# Altium schematic sheet SDCard_PMOD_JTAG.SchDoc(SDCard_PMOD_JTAG)
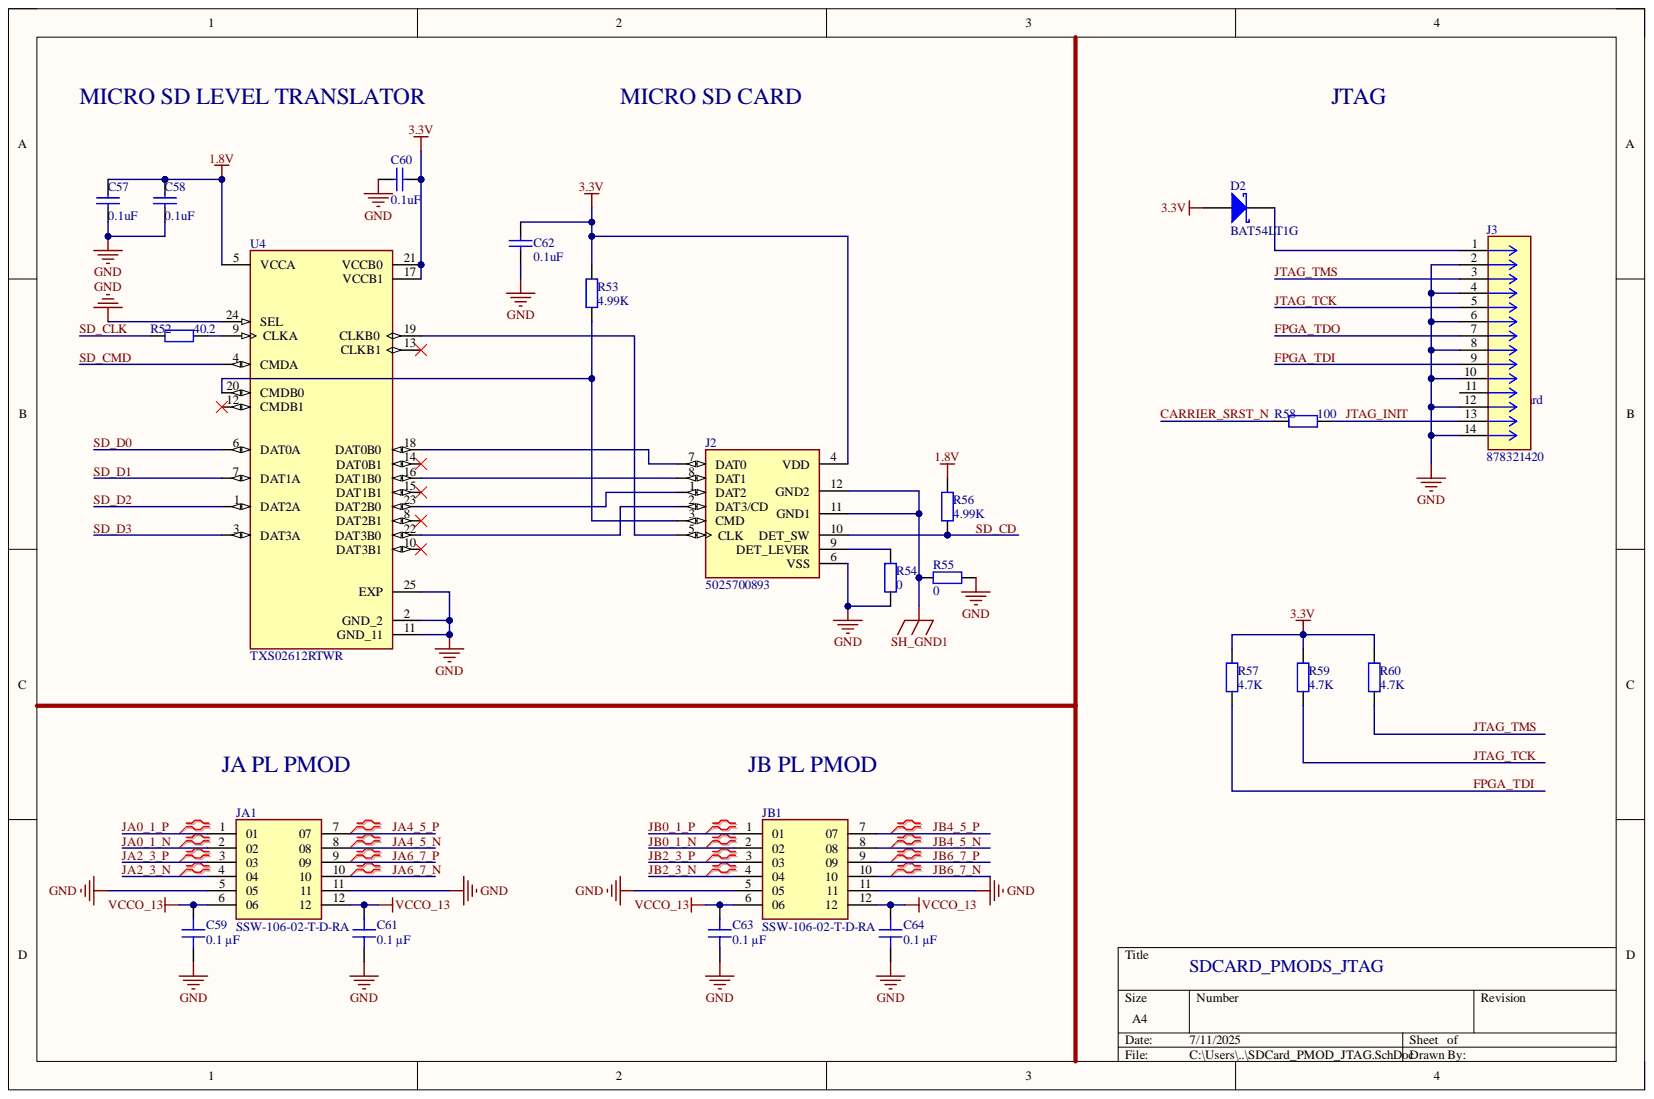
[diagram p0: SDCard_PMOD_JTAG.SchDoc]

## Parts
C57  value 0.1uF  [0603_C]  (hidden comment: Cap)
C58  value 0.1uF  [0603_C]  (hidden comment: Cap)
C59  0.1 µF  [CAP 0603_1608]
C60  value 0.1uF  [0603_C]  (hidden comment: Cap)
C61  0.1 µF  [CAP 0603_1608]
C62  value 0.1uF  [0603_C]  (hidden comment: Cap)
C63  0.1 µF  [CAP 0603_1608]
C64  0.1 µF  [CAP 0603_1608]
D2  BAT54LT1G  [SMB]
J2  5025700893  [MOLEX_5025700893]
J3  878321420  [878321420_MOL]
JA1  SSW-106-02-T-D-RA  [SSW-106-02-T-D-RA]
JB1  SSW-106-02-T-D-RA  [SSW-106-02-T-D-RA]
R52  value 40.2  [0603_R]  (hidden comment: Res2)
R53  value 4.99K  [0603_R]  (hidden comment: Res2)
R54  value 0  [0603_R]  (hidden comment: Res2)
R55  value 0  [0603_R]  (hidden comment: Res2)
R56  value 4.99K  [0603_R]  (hidden comment: Res2)
R57  value 4.7K  [0603_R]  (hidden comment: Res2)
R58  value 100  [0603_R]  (hidden comment: Res2)
R59  value 4.7K  [0603_R]  (hidden comment: Res2)
R60  value 4.7K  [0603_R]  (hidden comment: Res2)
U4  TXS02612RTWR  [TXS02612RTWR]

## Nets
1.8V: C57.2 C58.2 R56.2 U4.5(VCCA)
3.3V: C60.1 C62.1 D2.1 J2.4(VDD) R53.2 R57.2 R59.2 R60.2 U4.17(VCCB1) U4.21(VCCB0)
GND: C57.1 C58.1 C59.1 C60.2 C61.1 C62.2 C63.1 C64.1 J2.6(VSS) J3.2 J3.4 J3.6 J3.8 J3.10 J3.12 J3.14 JA1.5 JA1.11 JB1.5 JB1.11 R54.1 R55.1 U4.2(GND_2) U4.11(GND_11) U4.24(SEL) U4.25(EXP)
SH_GND1: J2.11(GND1) J2.12(GND2) R55.2
VCCO_13: C59.2 C61.2 C63.2 C64.2 JA1.6 JA1.12 JB1.6 JB1.12
CARRIER_SRST_N: R58.1
FPGA_TDI: J3.9 R57.1
FPGA_TDO: J3.7
JA0_1_N: JA1.2
JA0_1_P: JA1.1
JA2_3_N: JA1.4
JA2_3_P: JA1.3
JA4_5_N: JA1.8
JA4_5_P: JA1.7
JA6_7_N: JA1.10
JA6_7_P: JA1.9
JB0_1_N: JB1.2
JB0_1_P: JB1.1
JB2_3_N: JB1.4
JB2_3_P: JB1.3
JB4_5_N: JB1.8
JB4_5_P: JB1.7
JB6_7_N: JB1.10
JB6_7_P: JB1.9
JTAG_INIT: J3.13 R58.2
JTAG_TCK: J3.5 R59.1
JTAG_TMS: J3.3 R60.1
SD_CD: J2.10(DET_SW) R56.1
SD_CLK: R52.1
SD_CMD: U4.4(CMDA)
SD_D0: U4.6(DAT0A)
SD_D1: U4.7(DAT1A)
SD_D2: U4.1(DAT2A)
SD_D3: U4.3(DAT3A)
NetD2_2: D2.2 J3.1
NetJ2_1: J2.1(DAT2) U4.23(DAT2B0)
NetJ2_2: J2.2(DAT3/CD) U4.22(DAT3B0)
NetJ2_3: J2.3(CMD) R53.1 U4.20(CMDB0)
NetJ2_5: J2.5(CLK) U4.19(CLKB0)
NetJ2_7: J2.7(DAT0) U4.18(DAT0B0)
NetJ2_8: J2.8(DAT1) U4.16(DAT1B0)
NetJ2_9: J2.9(DET_LEVER) R54.2
NetR52_2: R52.2 U4.9(CLKA)

Not connected: J3 11; U4 8(DAT2B1) 10(DAT3B1) 12(CMDB1) 13(CLKB1) 14(DAT0B1) 15(DAT1B1)

## Library data of the parts
C57 C58 C60 C62 = Cap: Capacitor; Component Kind: Standard; LatestRevisionDate: 17-Jul-2002; LatestRevisionNote: Re-released for DXP Platform.; Library Name: Miscellaneous Devices.IntLib; PackageDescription: Radial Cap, Thru-Hole; 2 Leads; 0.3 in Pin Spacing; PackageReference: RAD-0.3; Published: 8-Jun-2000; Signal Integrity: Cap; Simulation: CAP; Publisher: Altium Limited
C59 C61 C63 C64 = C0603X104M3RAC7867: CAP CER 0.1UF 25V X7R 0603; manufacturer: KEMET; Database Table Name: Capacitors - Ceramic - 0603; Tolerance: ±20%; Applications: Boardflex Sensitive; Capacitance: 0.1 µF; Component Kind: Standard; componentlink1description: Datasheet; componentlink1url: https://api.kemet.com/component-edge/download/datasheet/C0603X104M3RACTU.pdf; componentlink2description: DigiKey Link; componentlink2url: https://www.digikey.com/en/products/detail/kemet/C0603X104M3RAC7867/2202613; Device Package: 0603 (1608 Metric); Features: Soft Termination; lastupdated: 2024/2/9 20:10:30; Library Name: Celestial Altium Library - altium_library.DbLib; Lifecycle Status: Active; Minimum Order: 1; Mounting Type: Surface Mount, MLCC; Operating Temperature: -55°C ~ 125°C; Package / Case: 0603 (1608 Metric); packaging: TapeAndReel; Part Number: C0603X104M3RAC7867; Part Status: Active; partid: 2484; price: 0.06; series: SMD Comm X7R Flex; Size / Dimension: 0.063" L x 0.031" W (1.60mm x 0.80mm); Supplier 1: DigiKey; Supplier Part Number 1: 399-13148-1-ND; Temperature Coefficient: X7R; Thickness (Max): 0.034" (0.87mm); value: 0.1 µF; Voltage - Rated: 25V
D2 = D Schottky: Schottky Diode; Code_JEDEC: DO-214-AA; Component Kind: Standard; LatestRevisionDate: 17-Jul-2002; LatestRevisionNote: Re-released for DXP Platform.; Library Name: Miscellaneous Devices.IntLib; PackageDescription: DO-214-AA/SMB; 2 C-Bend Leads; Body 5.3 x 3.6 mm, inc. leads (LxW); PackageReference: SMB; Published: 8-Jun-2000; Signal Integrity: D Schottky; Simulation: SKYDIODE; Publisher: Altium Limited
R52-R60 = Res2: Resistor; Component Kind: Standard; LatestRevisionDate: 17-Jul-2002; LatestRevisionNote: Re-released for DXP Platform.; Library Name: Miscellaneous Devices.IntLib; PackageDescription: Axial Device, Thru-Hole; 2 Leads; 0.4 in Pin Spacing; PackageReference: AXIAL-0.4; Published: 8-Jun-2000; Signal Integrity: Res; Simulation: RESISTOR; Publisher: Altium Limited
J2 = 5025700893: Component Kind: Standard; Library Name: PCB.SchLib
J3 = 878321420: Component Kind: Standard; Library Name: PCB.SchLib
JA1 JB1 = SSW-106-02-T-D-RA: Component Kind: Standard; Library Name: PCB.SchLib
U4 = TXS02612RTWR: Component Kind: Standard; Library Name: PCB.SchLib
